# Altium schematic sheet TOP.SchDoc
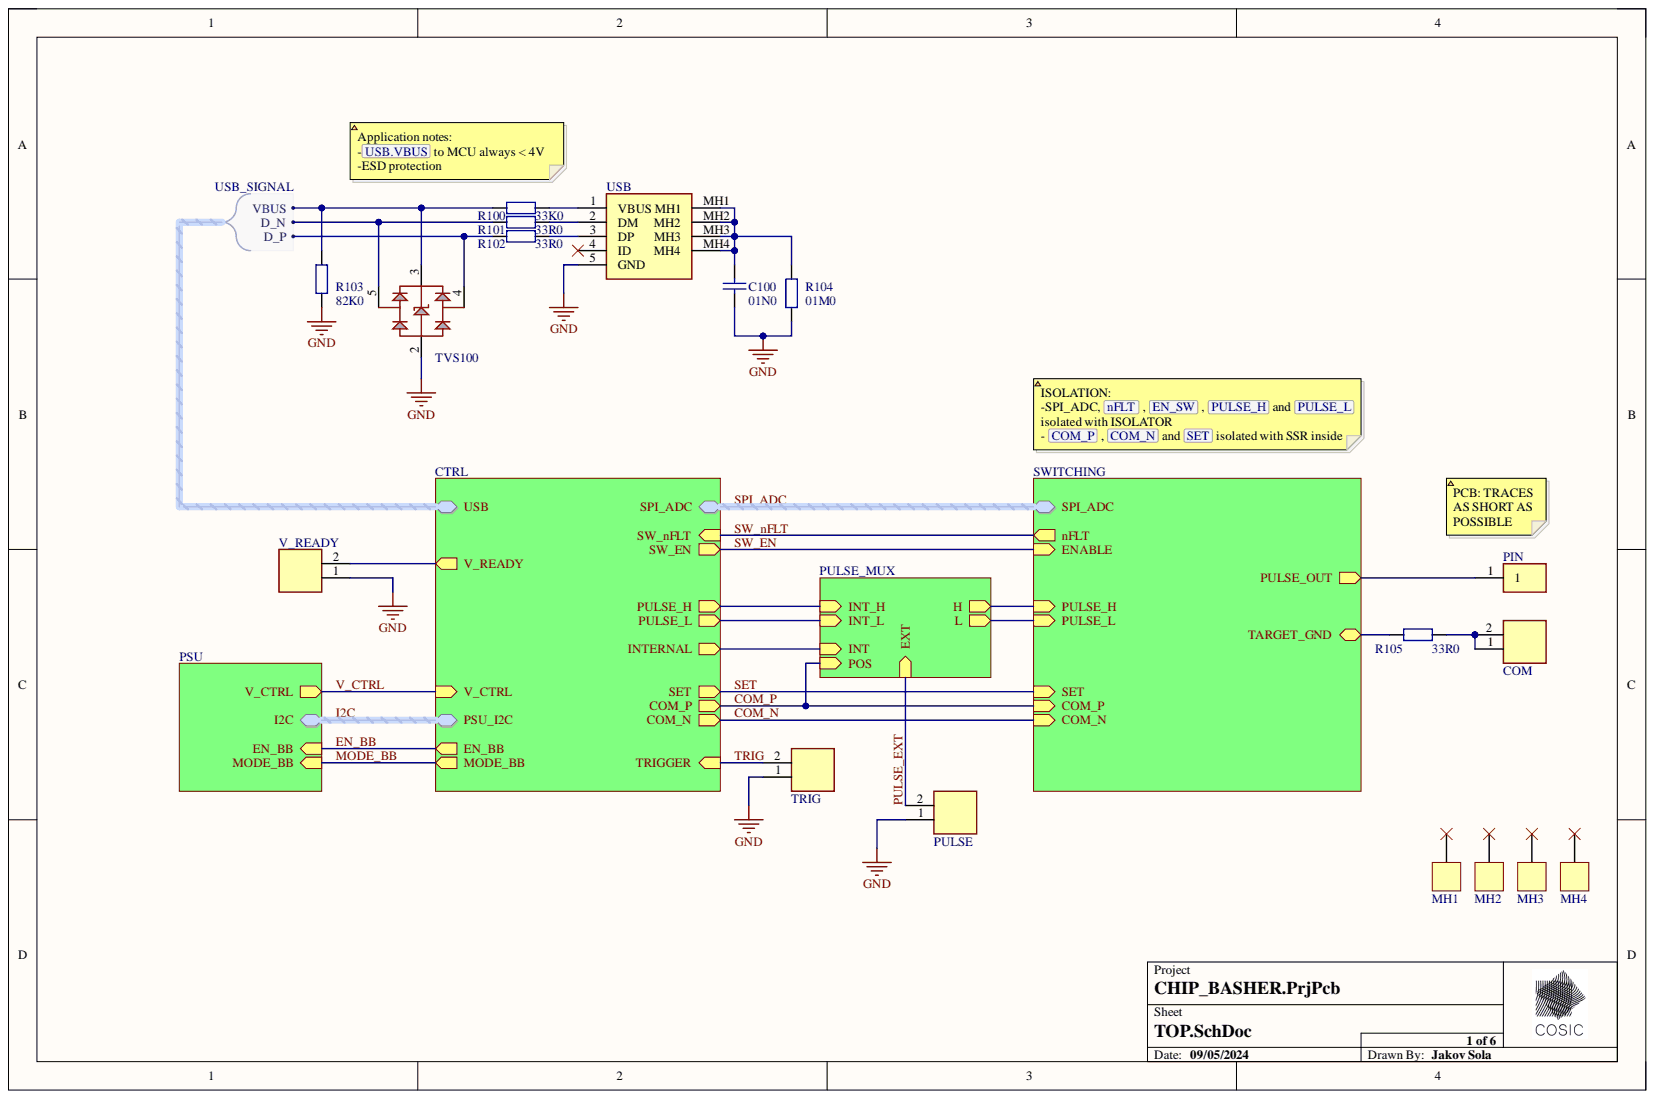
[diagram p0: TOP.SchDoc]

## Parts
C100  VALUE_4C: 01N0  [CAPC0805N]  (hidden comment: Cap)
COM  CON_2P  [2MMHBS02VT02HTB]  (value not drawn)
MH1  MH  [MH]  (no BOM)
MH2  MH  [MH]  (no BOM)
MH3  MH  [MH]  (no BOM)
MH4  MH  [MH]  (no BOM)
PIN  CON_1P  [POGO]  (value not drawn)
PULSE  CON_2P  [2MMHBS02VT02HTB]  (value not drawn)
R100  VALUE_4C: 33K0  [RESC0603N]  (hidden comment: Res)
R101  VALUE_4C: 33R0  [RESC0603N]  (hidden comment: Res)
R102  VALUE_4C: 33R0  [RESC0603N]  (hidden comment: Res)
R103  VALUE_4C: 82K0  [RESC0603N]  (hidden comment: Res)
R104  VALUE_4C: 01M0  [RESC0603N]  (hidden comment: Res)
R105  VALUE_4C: 33R0  [RESC0603N]  (hidden comment: Res)
TRIG  CON_2P  [2MMHBS02VT02HTB]  (value not drawn)
TVS100  SP3003_2X  [SC70_5]  (value not drawn)
USB  microUSB_CON  [476420001]  (value not drawn)
V_READY  CON_2P  [2MMHBS02VT02HTB]  (value not drawn)

## Nets
GND: C100.1 PULSE.1 R103.1 R104.1 TRIG.1 TVS100.2 USB.5(GND) V_READY.1
PULSE_EXT: PULSE.2
TRIG: TRIG.2
NetC100_2: C100.2 R104.2 USB.MH1 USB.MH2 USB.MH3 USB.MH4
NetCOM_1: COM.1 COM.2 R105.2
NetMH1_0: MH1.0
NetMH2_0: MH2.0
NetMH3_0: MH3.0
NetMH4_0: MH4.0
NetPIN_1: PIN.1
NetR100_1: R100.1 USB.1(VBUS)
NetR100_2: R100.2 R103.2 TVS100.3
NetR101_1: R101.1 TVS100.5
NetR101_2: R101.2 USB.2(DM)
NetR102_1: R102.1 TVS100.4
NetR102_2: R102.2 USB.3(DP)
NetR105_1: R105.1
NetUSB_4: USB.4(ID)
NetV_READY_2: V_READY.2
COM_N:
COM_P:
EN_BB:
H:
I2C.SCL:
I2C.SDA:
INT:
INT_H:
INT_L:
L:
MODE_BB:
SET:
SPI_ADC.MISO:
SPI_ADC.nCS:
SPI_ADC.SCK:
SW_EN:
SW_nFLT:
V_CTRL:

## Library data of the parts
C100 = 2238 600 15623: MANUF: PHYCOMP; Database Table Name: Fixed_capacitors$; DESCR: CAP 01N0 0805 100V X7R 10%; POPULATE: YES; TOLERANCE: 0.1; VALUE_PF: 1000; VENDOR: FARNELL INONE; VENDORCODE: 1362553; VOLTAGE: 100V; Component Kind: Standard; Library Name: Capacitors.DbLib; MANUFCODE: 2238 600 15623; PACKAGE: 0805; TECHNOLOGY: X7R
COM PULSE TRIG V_READY = 2MM-HB-S02-VT-02-H-TB: MANUF: TE CONNECTIVITY; Database Table Name: Misc$; DESCR: CON 2P 2MM HEADER; POPULATE: YES; VENDOR: MOUSER; VENDORCODE: 571-2MM-HBS02VT02HTB; Component Kind: Standard; Library Name: Other_passive.Dblib; MANUFCODE: 2MM-HB-S02-VT-02-H-TB
MH1-MH4 = MH: Database Table Name: Misc$; DESCR: MOUNTING HOLE; POPULATE: NA; Library Name: Other_passive.Dblib; MANUFCODE: MH
PIN = P25-0123: MANUF: HARWIN; Database Table Name: Misc$; DESCR: POGO PIN 15X5MM; POPULATE: YES; VENDOR: MOUSER; VENDORCODE: 855-P25-0123; Component Kind: Standard; Library Name: Other_passive.Dblib; MANUFCODE: P25-0123
R100 = CRCW060333K0FKEA: Resistor; MANUF: VISHAY; Database Table Name: Fixed_resistors$; DESCR: RES 33K0 0603 0.1W 1% 75V 100PPM; NPOWER: 0.1; NTEMPCOEFF: 100; NTOLERANCE: 0.01; NVALUE: 33000000; NVOLTAGE: 75; POPULATE: YES; POWER: 0.1W; TEMPCOEFF: 100PPM; TOLERANCE: 1%; VALUE: 33K; VENDOR: DIGI-KEY; VENDORCODE: 541-33.0KHCT-ND; VOLTAGE: 75V; Component Kind: Standard; Library Name: Resistors.Dblib; MANUFCODE: CRCW060333K0FKEA; PACKAGE: 0603; VALUE_MOHM: 33000000
R101 R102 R105 = CRCW060333R0FKEA: Resistor; MANUF: VISHAY; Database Table Name: Fixed_resistors$; DESCR: RES 33R0 0603 0.1W 1% 75V 100PPM; NPOWER: 0.1; NTEMPCOEFF: 100; NTOLERANCE: 0.01; NVALUE: 33000; NVOLTAGE: 75; POPULATE: YES; POWER: 0.1W; TEMPCOEFF: 100PPM; TOLERANCE: 1%; VALUE: 33R; VENDOR: DIGI-KEY; VENDORCODE: 541-33.0HCT-ND; VOLTAGE: 75V; Component Kind: Standard; Library Name: Resistors.Dblib; MANUFCODE: CRCW060333R0FKEA; PACKAGE: 0603; VALUE_MOHM: 33000
R103 = CRCW060382K0FKEA: Resistor; MANUF: VISHAY; Database Table Name: Fixed_resistors$; DESCR: RES 82K0 0603 0.1W 1% 75V 100PPM; NPOWER: 0.1; NTEMPCOEFF: 100; NTOLERANCE: 0.01; NVALUE: 82000000; NVOLTAGE: 75; POPULATE: YES; POWER: 0.1W; TEMPCOEFF: 100PPM; TOLERANCE: 1%; VALUE: 82K; VENDOR: DIGI-KEY; VENDORCODE: 541-82.0KHCT-ND; VOLTAGE: 75V; Component Kind: Standard; Library Name: Resistors.Dblib; MANUFCODE: CRCW060382K0FKEA; PACKAGE: 0603; VALUE_MOHM: 82000000
R104 = MC 0.063W 0603 1% 1M: Resistor; MANUF: MULTICOMP; Database Table Name: Fixed_resistors$; DESCR: RES 01M0 0603 0.063W 1% 50V 100PPM; NPOWER: 0.063; NTEMPCOEFF: 100; NTOLERANCE: 0.01; NVALUE: 1000000000; NVOLTAGE: 50; POPULATE: YES; POWER: 0.063W; TEMPCOEFF: 100PPM; TOLERANCE: 1%; VALUE: 1M; VENDOR: FARNELL INONE; VENDORCODE: 9330410; VOLTAGE: 50V; Component Kind: Standard; Library Name: Resistors.Dblib; MANUFCODE: MC 0.063W 0603 1% 1M; PACKAGE: 0603; PCB3D: RES0603; VALUE_MOHM: 1000000000
TVS100 = SP3003-02JTG: MANUF: LITTELFUSE; Database Table Name: Power_diodes$; DESCR: DIODE TVS DUAL 0.65PF SC70-5; POPULATE: YES; VENDOR: DIGI-KEY; VENDORCODE: 1827640; Component Kind: Standard; Library Name: Diodes.Dblib; MANUFCODE: SP3003-02JTG; PACKAGE: SC70_5; QTY: DUAL; TYPE: TVS_BIDIR
USB = 47642-0001: MANUF: MOLEX; Database Table Name: Misc$; DESCR: CON MICRO USB 5P SMD; POPULATE: YES; VENDOR: MOUSER; VENDORCODE: 538-47642-0001; Component Kind: Standard; Library Name: Other_passive.Dblib; MANUFCODE: 47642-0001
